# Altium schematic sheet OSP_Carrier_V7_Release_SD.SchDoc
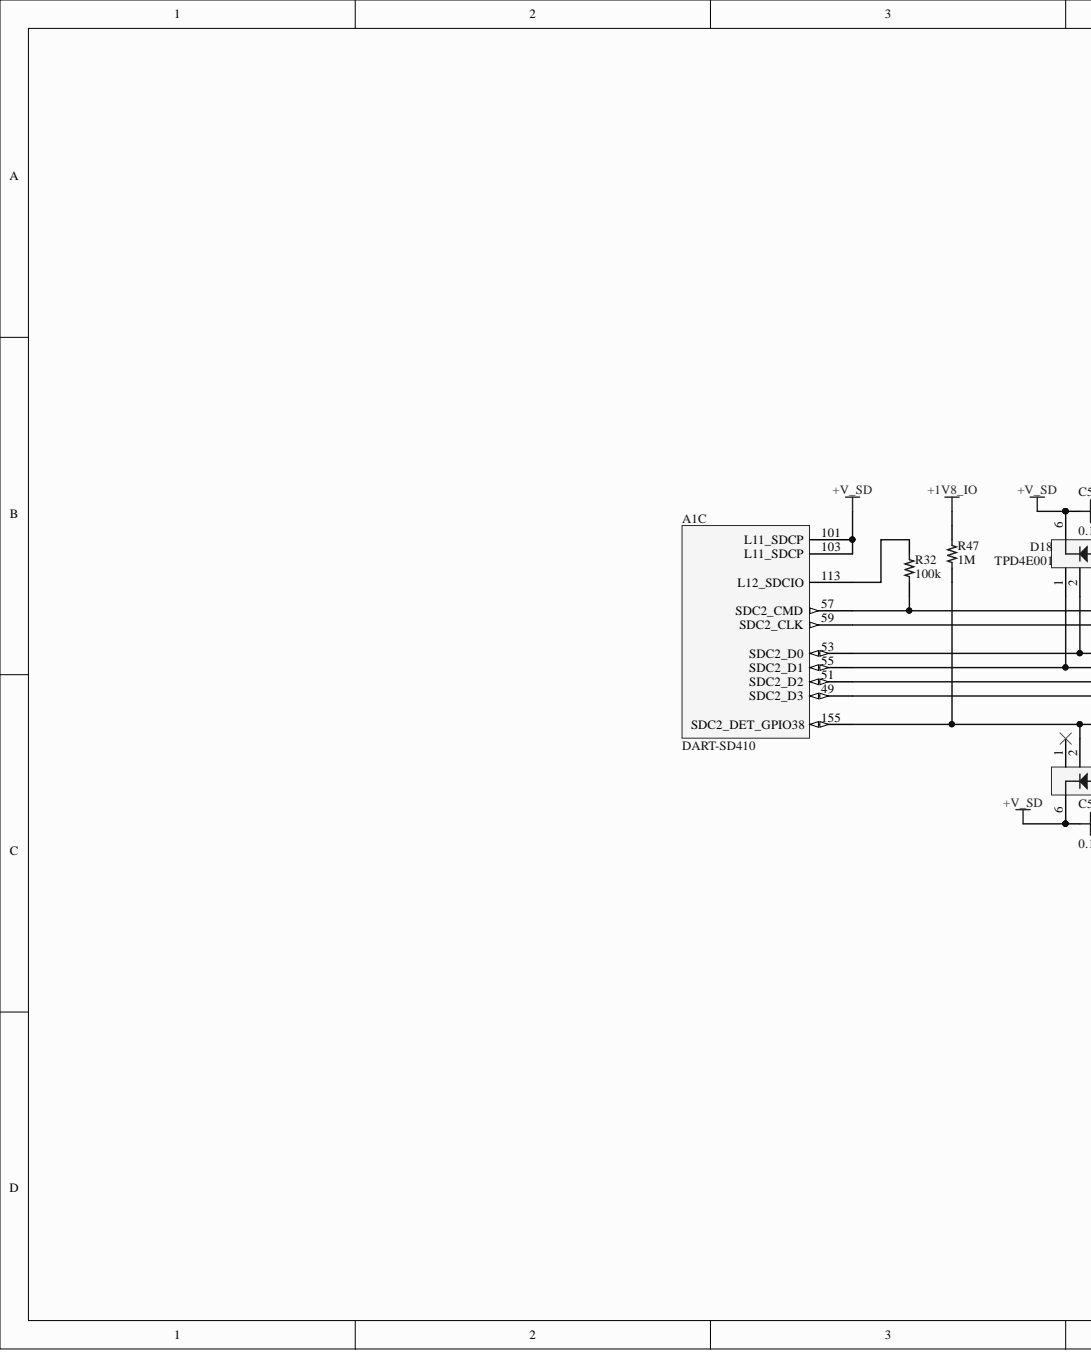
[diagram p0: OSP_Carrier_V7_Release_SD.SchDoc, left]
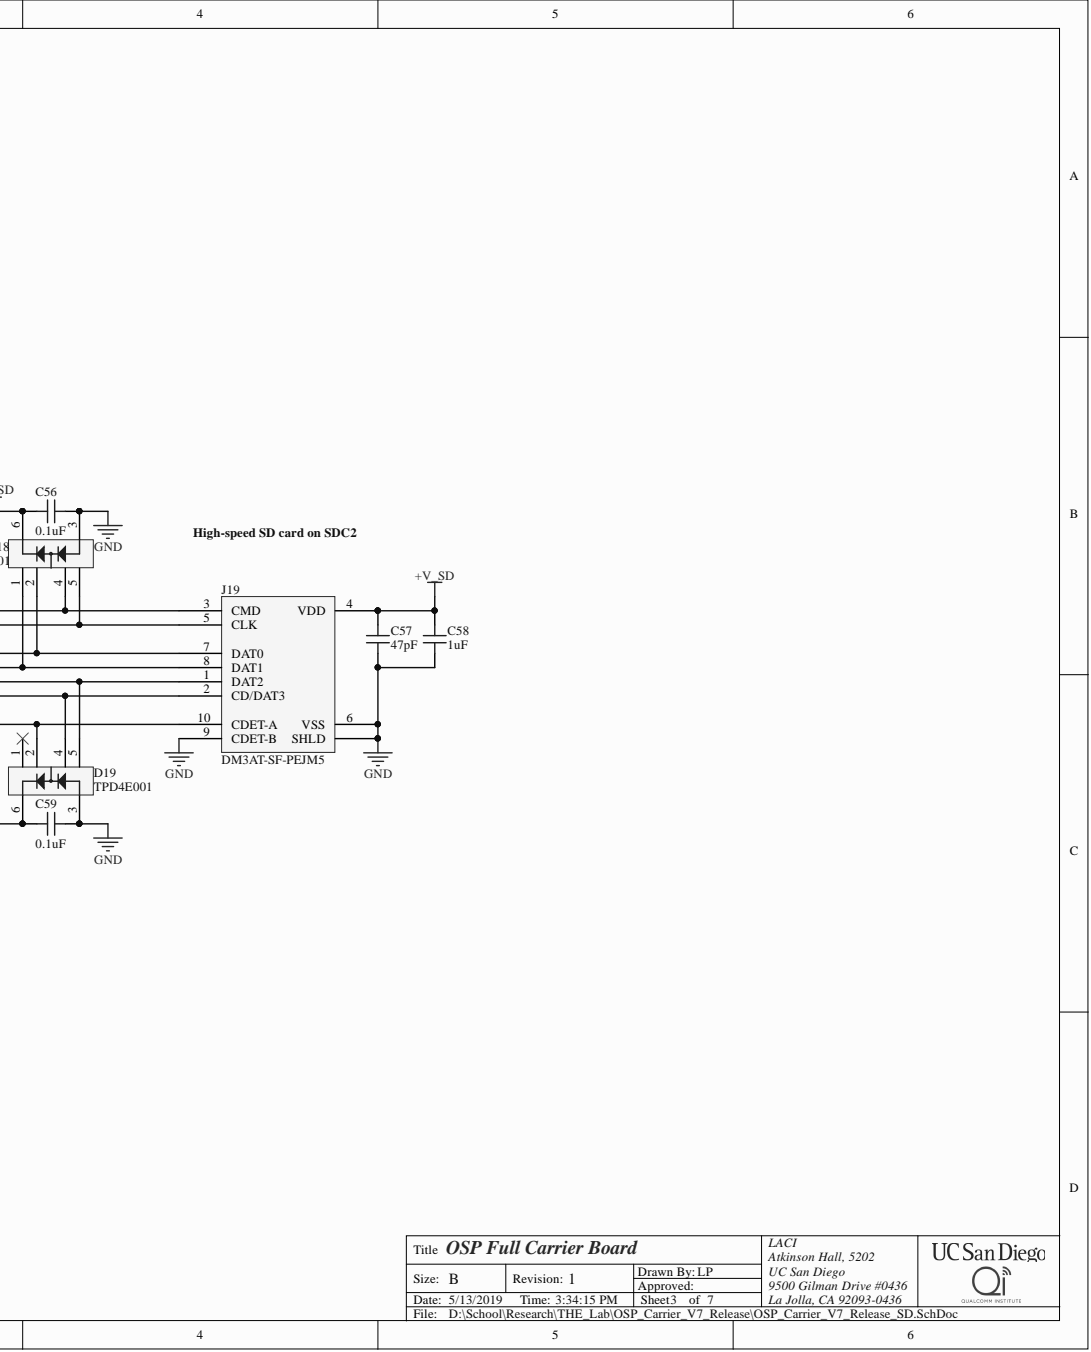
[diagram p1: OSP_Carrier_V7_Release_SD.SchDoc, right]

## Parts
A1  DART-SD410  [DART-SD410]  (part C)
C56  0.1uF  [CAP 0402/1005]
C57  47pF  [CAP 0402/1005]
C58  1uF  [CAP 0603/1608]
C59  0.1uF  [CAP 0402/1005]
D18  TPD4E001  [TI_SOT-563_DRL]
D19  TPD4E001  [TI_SOT-563_DRL]
J19  DM3AT-SF-PEJM5  [HIROSE DM3AT-SF-PEJM5]
R32  100k  [RES 0402/1005]
R47  1M  [RES 0402/1005]

## Nets
+1V8_IO: R47.2
+V_SD: A1.101(L11_SDCP) A1.103(L11_SDCP) C56.2 C57.2 C58.2 C59.2 D18.6 D19.6 J19.4(VDD)
GND: C56.1 C57.1 C58.1 C59.1 D18.3 D19.3 J19.6(VSS) J19.9(CDET-B) J19.S(SHLD)
NetA1_49: A1.49(SDC2_D3) D19.4 J19.2(CD/DAT3)
NetA1_51: A1.51(SDC2_D2) D19.5 J19.1(DAT2)
NetA1_53: A1.53(SDC2_D0) D18.2 J19.7(DAT0)
NetA1_55: A1.55(SDC2_D1) D18.1 J19.8(DAT1)
NetA1_57: A1.57(SDC2_CMD) D18.4 J19.3(CMD) R32.1
NetA1_59: A1.59(SDC2_CLK) D18.5 J19.5(CLK)
NetA1_113: A1.113(L12_SDCIO) R32.2
NetA1_155: A1.155(SDC2_DET_GPIO38) D19.2 J19.10(CDET-A) R47.1

Not connected: D19 1

## Library data of the parts
A1 = DART-SD410: Variscite DART-SD410 System on Module; Component Kind: Standard; Library Name: DART-SD410.SchLib
C56 C59 = C1005X7R1H104K050BB: CAP CER 0.1UF 50V X7R 0402; Manufacturer: TDK Corporation; Database Table Name: Capacitor - Ceramic; TemperatureRange: -55C ~ 125C; Tolerance: 10%; Component Kind: Standard; ComponentLink1Description: Datasheet; ComponentLink1URL: https://product.tdk.com/info/en/catalog/datasheets/mlcc_commercial_general_en.pdf; ComponentLink2Description: Digi-Key listing; ComponentLink2URL: http://digikey.com/product-detail/en/C1005X7R1H104K050BB/445-5932-2-ND/2443410; Device Package: 0402 (1005 Metric); Library Name: Celestial Library Database.DbLib; PartId: 3860; Part Number: C1005X7R1H104K050BB; Price: 0.0287; Supplier 1: Digi-Key; Supplier Part Number 1: 445-5932-2-ND; TemperatureCoefficient: X7R; Type: -; Value: 0.1uF; Voltage: 50V
C57 = C1005C0G1H470G050BA: CAP CER 47PF 50V C0G 0402; Manufacturer: TDK Corporation; Database Table Name: Capacitor - Ceramic; TemperatureCoefficient: C0G, NP0; TemperatureRange: -55C ~ 125C; Tolerance: 2%; Component Kind: Standard; ComponentLink1Description: Datasheet; ComponentLink1URL: https://product.tdk.com/info/en/catalog/datasheets/mlcc_commercial_general_en.pdf; ComponentLink2Description: Digi-Key listing; ComponentLink2URL: http://digikey.com/product-detail/en/C1005C0G1H470G050BA/445-5364-2-ND/2269858; Device Package: 0402 (1005 Metric); Library Name: Celestial Library Database.DbLib; PartId: 3864; Part Number: C1005C0G1H470G050BA; Price: 0.1148; Supplier 1: Digi-Key; Supplier Part Number 1: 445-5364-2-ND; Type: -; Value: 47pF; Voltage: 50V
C58 = C1608X7R1E105K080AB: CAP CER 1UF 25V X7R 0603; Manufacturer: TDK Corporation; Database Table Name: Capacitor - Ceramic; TemperatureRange: -55C ~ 125C; Tolerance: 10%; Component Kind: Standard; ComponentLink1Description: Datasheet; ComponentLink1URL: https://product.tdk.com/info/en/catalog/datasheets/mlcc_commercial_general_en.pdf; ComponentLink2Description: Digi-Key listing; ComponentLink2URL: http://digikey.com/product-detail/en/C1608X7R1E105K080AB/445-5956-1-ND/2443997; Device Package: 0603 (1608 Metric); Library Name: Celestial Library Database.DbLib; PartId: 4041; Part Number: C1608X7R1E105K080AB; Price: 0.27; Supplier 1: Digi-Key; Supplier Part Number 1: 445-5956-1-ND; TemperatureCoefficient: X7R; Type: -; Value: 1uF; Voltage: 25V
D18 D19 = TI_TPD4E001: TI TPD4E001 4-channel TVS ESD protection diodes; Component Kind: Standard; Library Name: OSP_Symbols.SchLib
J19 = DM3AT-SF-PEJM5: CONN MICRO SD CARD PUSH-PUSH R/A; Manufacturer: Hirose Electric Co Ltd; Connector Type: Connector and Ejector; Database Table Name: Connector - SD; Number of Positions: 10 (8 + 2); Component Kind: Standard; ComponentLink1Description: Datasheet; ComponentLink1URL: http://www.hirose.co.jp/cataloge_hp/e60900232.pdf; ComponentLink2Description: Digi-Key Listing; ComponentLink2URL: http://www.digikey.ca/product-detail/en/DM3AT-SF-PEJM5/HR1964TR-ND/2533565; Contact Finish: Gold; Features: Switch; Library Name: Celestial Library Database.DbLib; Mounting Type: Surface Mount, Right Angle; PartId: 9287; Part Number: DM3AT-SF-PEJM5; Price: 2.1114; Supplier 1: Digi-Key; Supplier Part Number 1: HR1964TR-ND; Type: Connector and Ejector
R32 = ERJ-2RKF1003X: RES SMD 100K OHM 1% 1/10W 0402; Database Table Name: Resistor - Chip; Value: 1K; Component Kind: Standard; Library Name: Celestial Library Database.DbLib; Part Number: ERJ-2RKF1003X
R47 = ERJ-2RKF1004X: RES SMD 1M OHM 1% 1/10W 0402; Manufacturer: Panasonic Electronic Components; Database Table Name: Resistor - Chip; PowerRating: 0.1W, 1/10W; TemperatureCoefficient: 100ppm/C; TemperatureRange: -55C ~ 155C; Component Kind: Standard; ComponentLink1Description: Datasheet; ComponentLink1URL: http://industrial.panasonic.com/www-cgi/jvcr13pz.cgi?E+PZ+3+AOA0002+ERJ2RKF1004X+7+WW; ComponentLink2Description: Digikey listing; ComponentLink2URL: http://digikey.com/product-detail/en/ERJ-2RKF1004X/P1.00MLTR-ND/79728; Device Package: 0402 (1005 Metric); Library Name: Celestial Library Database.DbLib; PartId: 7; Part Number: ERJ-2RKF1004X; Price: 0.0043; Supplier 1: Digi-Key; Supplier Part Number 1: P1.00MLTR-ND; Tolerance: 1%; Type: Thick Film; Value: 1M
